# Altium schematic sheet TOP.SchDoc
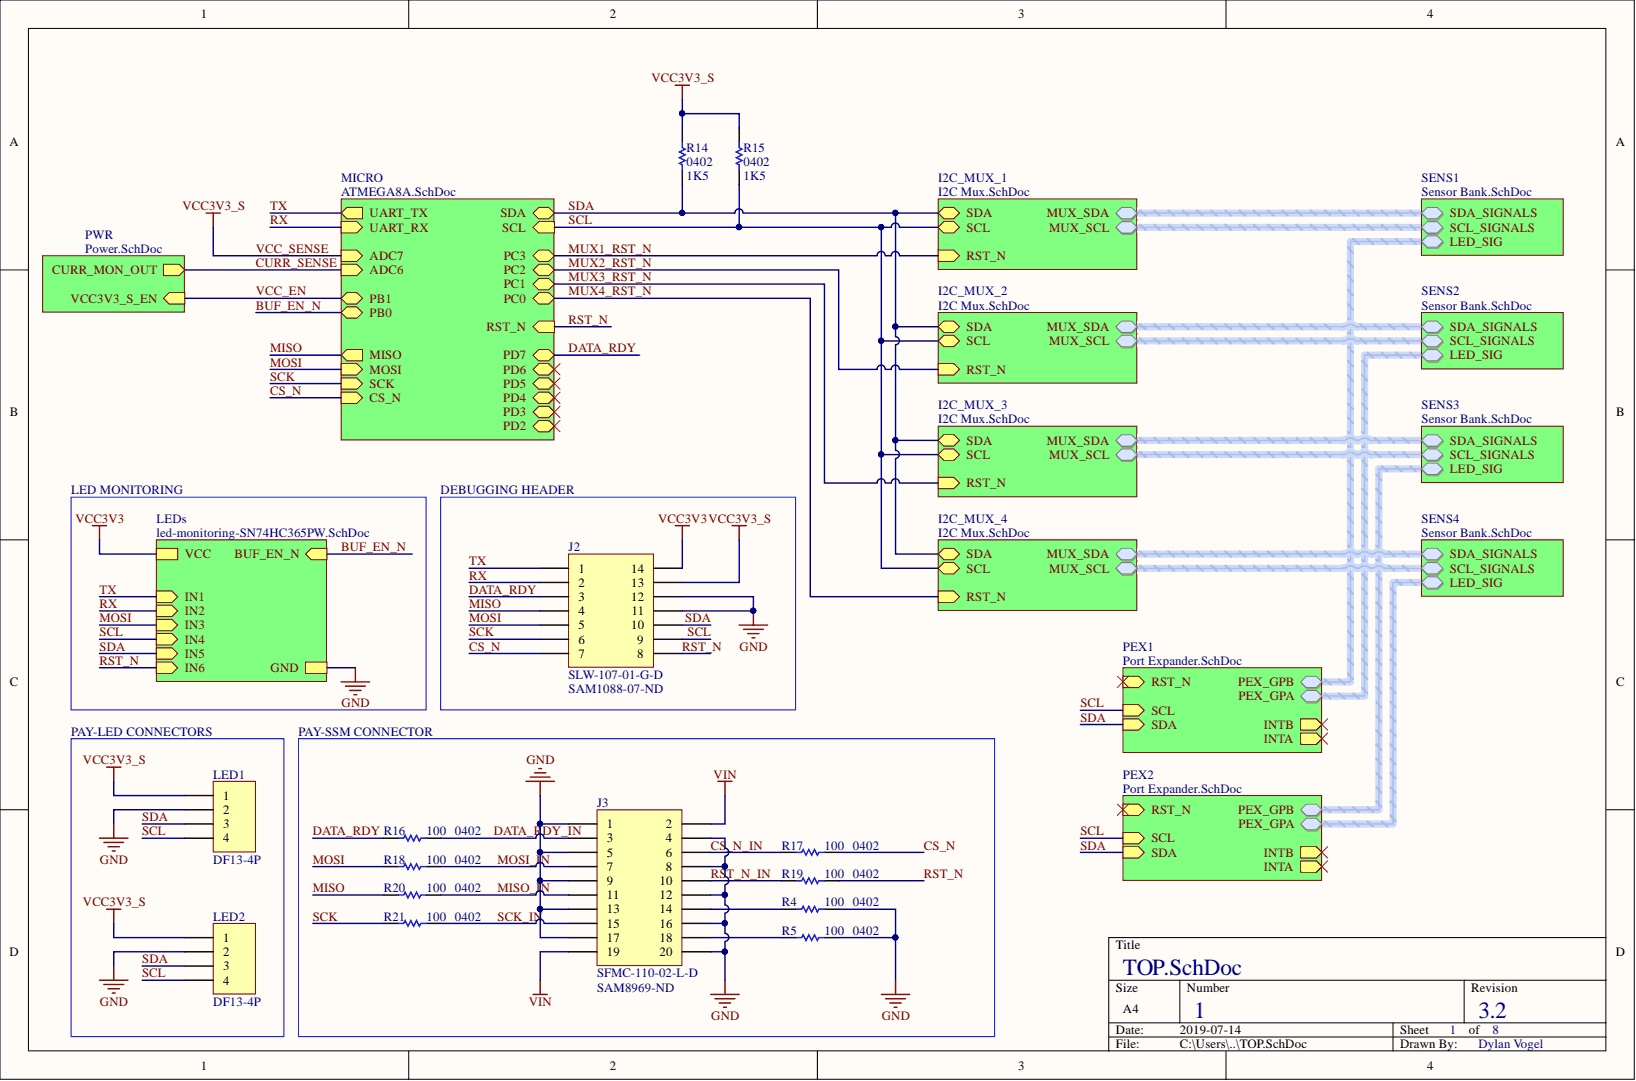
[diagram p0: TOP.SchDoc]

## Parts
J2  SLW-107-01-G-D  Supplier Part Number 1: SAM1088-07-ND  [SLW-107-01-X-D]
J3  SFMC-110-02-L-D  Supplier Part Number 1: SAM8969-ND  [SFMC-110-02-L-D]
LED1  DF13-4P  [DF13A-4P-1.25H(51)]
LED2  DF13-4P  [DF13A-4P-1.25H(51)]
R4  0402  value 100  [RES0402R]
R5  0402  value 100  [RES0402R]
R14  0402  value 1K5  [RES0402R]
R15  0402  value 1K5  [RES0402R]
R16  0402  value 100  [RES0402R]
R17  0402  value 100  [RES0402R]
R18  0402  value 100  [RES0402R]
R19  0402  value 100  [RES0402R]
R20  0402  value 100  [RES0402R]
R21  0402  value 100  [RES0402R]

## Nets
GND: J2.6 J2.8 J3.1 J3.4 J3.5 J3.8 J3.9 J3.12 J3.13 J3.16 J3.17 J3.20 LED1.2 LED2.2 R4.2 R5.2
VCC3V3: J2.2
VIN: J3.2 J3.19
CS_N: J2.13 R17.2
CS_N_IN: J3.6 R17.1
DATA_RDY: J2.5 R16.1
DATA_RDY_IN: J3.3 R16.2
MISO: J2.7 R20.1
MISO_IN: J3.11 R20.2
MOSI: J2.9 R18.1
MOSI_IN: J3.7 R18.2
RST_N: J2.14 R19.2
RST_N_IN: J3.10 R19.1
RX: J2.3
SCK: J2.11 R21.1
SCK_IN: J3.15 R21.2
SCL: J2.12 LED1.4 LED2.4 R15.1
SDA: J2.10 LED1.3 LED2.3 R14.1
TX: J2.1
VCC3V3_S: J2.4 LED1.1 LED2.1 R14.2 R15.2
NetJ3_14: J3.14 R4.1
NetJ3_18: J3.18 R5.1
BUF_EN_N:
CURR_SENSE:
INTA:
INTB:
MUX1_RST_N:
MUX2_RST_N:
MUX3_RST_N:
MUX4_RST_N:
MUX_SCL.SC0:
MUX_SCL.SC1:
MUX_SCL.SC2:
MUX_SCL.SC3:
MUX_SCL.SC4:
MUX_SCL.SC5:
MUX_SCL.SC6:
MUX_SCL.SC7:
MUX_SDA.SD0:
MUX_SDA.SD1:
MUX_SDA.SD2:
MUX_SDA.SD3:
MUX_SDA.SD4:
MUX_SDA.SD5:
MUX_SDA.SD6:
MUX_SDA.SD7:
PD2:
PD3:
PD4:
PD5:
PD6:
PEX_GPA.LED1:
PEX_GPA.LED2:
PEX_GPA.LED3:
PEX_GPA.LED4:
PEX_GPA.LED5:
PEX_GPA.LED6:
PEX_GPA.LED7:
PEX_GPA.LED8:
PEX_GPB.LED1:
PEX_GPB.LED2:
PEX_GPB.LED3:
PEX_GPB.LED4:
PEX_GPB.LED5:
PEX_GPB.LED6:
PEX_GPB.LED7:
PEX_GPB.LED8:
VCC_EN:

## Library data of the parts
LED1 LED2 = Header 4: Header, 4-Pin; Component Kind: Standard; LatestRevisionDate: 17-Jul-2002; LatestRevisionNote: Re-released for DXP Platform.; Library Name: Miscellaneous Connectors.IntLib; Signal Integrity: Connector; Publisher: Altium Limited
R4 R5 R14-R21 = Res1: Resistor; Component Kind: Standard; LatestRevisionDate: 17-Jul-2002; LatestRevisionNote: Re-released for DXP Platform.; Library Name: Miscellaneous Devices.IntLib; PackageDescription: Axial Device, Thru-Hole; 2 Leads; 0.3 in Pin Spacing; PackageReference: AXIAL-0.3; Published: 8-Jun-2000; Signal Integrity: Res; Simulation: RESISTOR; Supplier 1: Digi-Key; Publisher: Altium Limited
J2 = SLW-107-01-G-D: Component Kind: Standard; Supplier 1: Digi-Key; Library Name: heron-general.IntLib
J3 = SFMC-110-02-L-D: Component Kind: Standard; Supplier 1: Digi-Key; Library Name: heron-general.IntLib
